# Altium schematic sheet UART_TO_USB_TTL.SchDoc
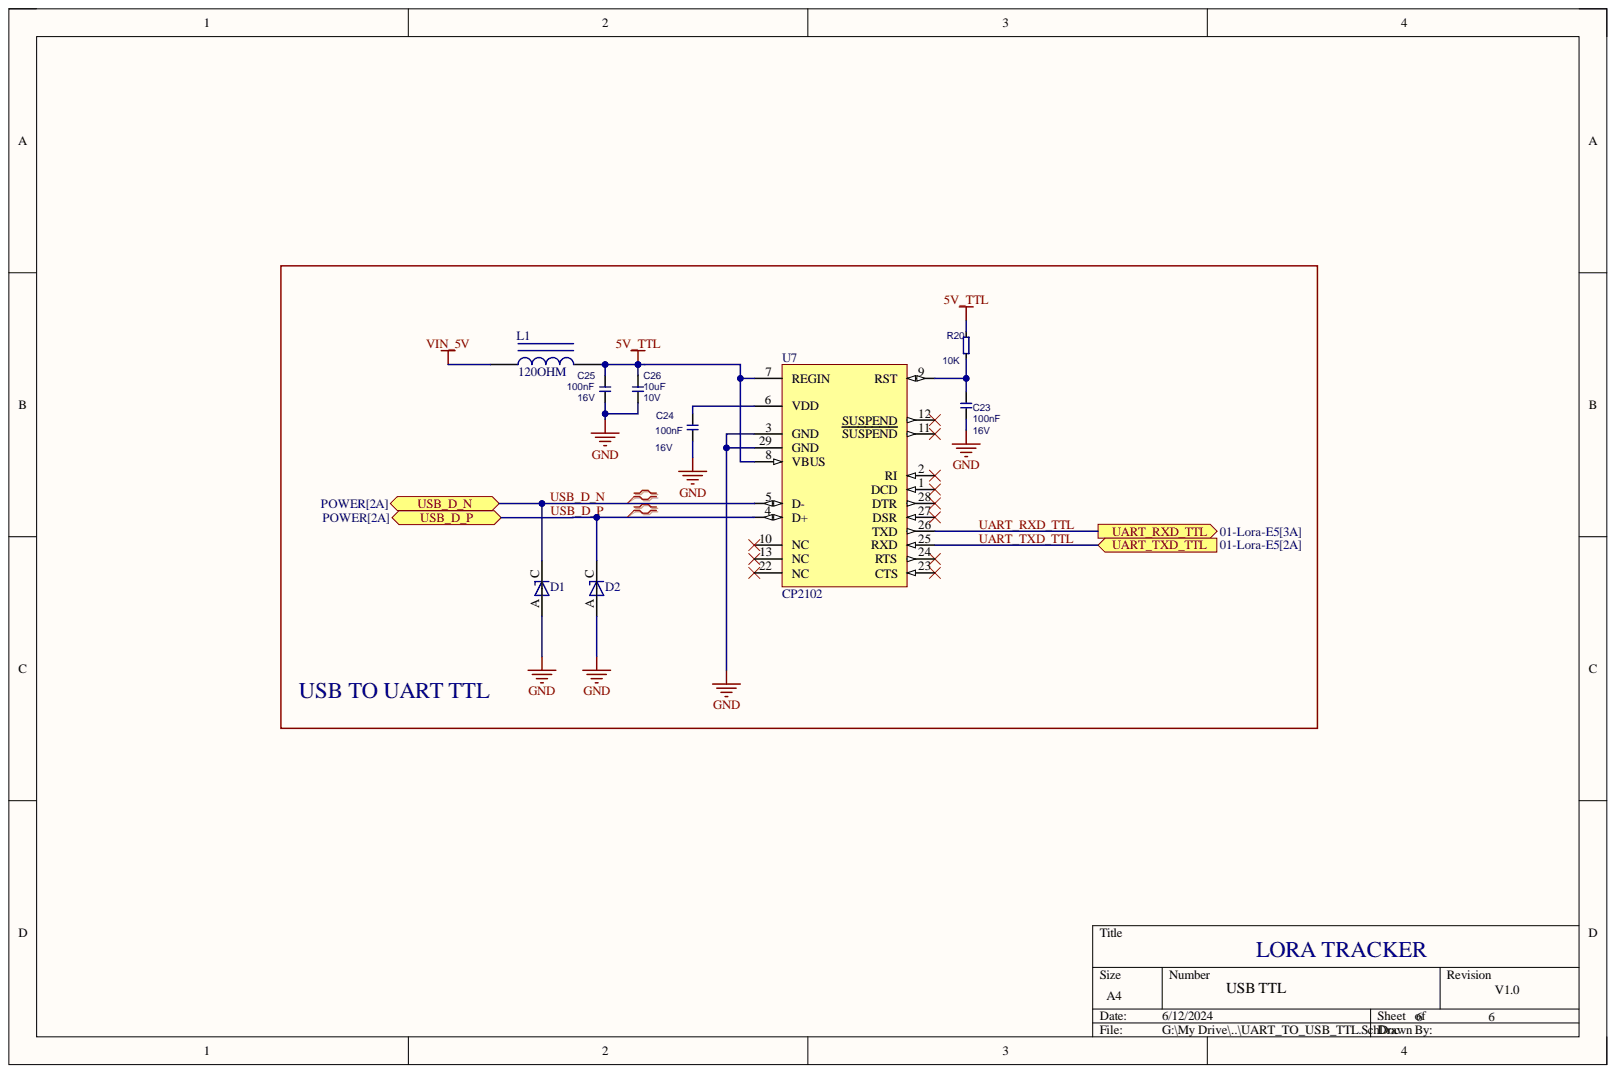
[diagram p0: UART_TO_USB_TTL.SchDoc]

## Parts
C23  100nF  Rating: 16V  [CAPC1005X60N]
C24  100nF  Rating: 16V  [CAPC1005X60N]
C25  100nF  Rating: 16V  [CAPC1005X60N]
C26  10uF  Rating: 10V  [T_CAPC0603X33N]
D1  UCLAMP0561P.TNT  [TVS_ESDA25P35-1U1M]  (value not drawn)
D2  UCLAMP0561P.TNT  [TVS_ESDA25P35-1U1M]  (value not drawn)
L1  120 OHM  value 120OHM  [BEADC2012X105N]
R20  10K  [RESC1005X40N]
U7  CP2102  [QFN-28 - NEW]

## Ports
UART_RXD_TTL, UART_TXD_TTL, USB_D_N, USB_D_P

## Nets
5V_TTL: C25.2 C26.2 L1.2 R20.2 U7.7(REGIN) U7.8(VBUS)
GND: C23.2 C24.1 C25.1 C26.1 D1.A D2.A U7.3(GND) U7.29(GND)
VIN_5V: L1.1
UART_RXD_TTL: U7.26(TXD) ; port UART_RXD_TTL
UART_TXD_TTL: U7.25(RXD) ; port UART_TXD_TTL
USB_D_N: D1.C U7.5(D-) ; port USB_D_N
USB_D_P: D2.C U7.4(D+) ; port USB_D_P
NetC23_1: C23.1 R20.1 U7.9(RST)
NetC24_2: C24.2 U7.6(VDD)

Not connected: U7 1(DCD) 2(RI) 10(NC) 11(SUSPEND) 12(SUSPEND) 13(NC) 22(NC) 23(CTS) 24(RTS) 27(DSR) 28(DTR)

## Library data of the parts
C23-C25 = C-X7R-100nF-16V-10%-0603: CAP CER 0.1UF 16V X7R 0402; MP: CC0402KRX7R7BB104; Tolerance: 10%; Component Kind: Standard; Library Name: Libary_battery-power.SchLib; Type: X7R; Value: 100nF
C26 = C-X5R-4.7uF-10V-10%-0603: Capacitor Ceramic X5R 10uF 10V 10% 0603; Manufacturer Name: Generic; MP: CC0603KRX5R6BB106; Component Kind: Standard; Library Name: Libary_battery-power.SchLib; Tolerance: 10%; Type: X5R; Value: 10uF
D1 D2 = ESDA25P35-1U1M: 41V Clamp 35A (8/20Âµs) Ipp Tvs Diode Surface Mount 1610; MF: SEMTECH; Availability: Good; Component Kind: Standard; MP: UCLAMP0561P.TNT; Package: UDFN-2 STMicroelectronics; SnapEDA_Link: https://www.snapeda.com/parts/ESDA25P35-1U1M/STMicroelectronics/view-part/829806/?ref=snap; Value: TVS DIODE; Library Name: UDFN-2.IntLib
L1 = Inductor Iron: FERRITE BEAD 120 OHM 0805 1LN; MP: BLM21AG121SN1D; Component Kind: Standard; LatestRevisionDate: 17-Jul-2002; LatestRevisionNote: Re-released for DXP Platform.; Library Name: Miscellaneous Devices.IntLib; PackageDescription: Axial Device, Thru-Hole; 2 Leads; 0.9 in Pin Spacing; PackageReference: AXIAL-0.9; Published: 24-Mar-1999; Publisher: Altium Limited
R20 = R-10KR-63mW-5%-0603: RES 10K OHM 5% 1/16W 0402; MP: RC0402JR-13330RL; Rating: 63mW; Value: 330R; Component Kind: Standard; Library Name: Libary_battery-power.SchLib; Tolerance: 5%
U7 = CP2102: IC USB-TO-UART BRIDGE 28VQFN; MP: CP2102-GMR; Component Kind: Standard; Library Name: BLE KEYBOARD.SCHLIB
